# Altium schematic sheet C2000Connector.SchDoc
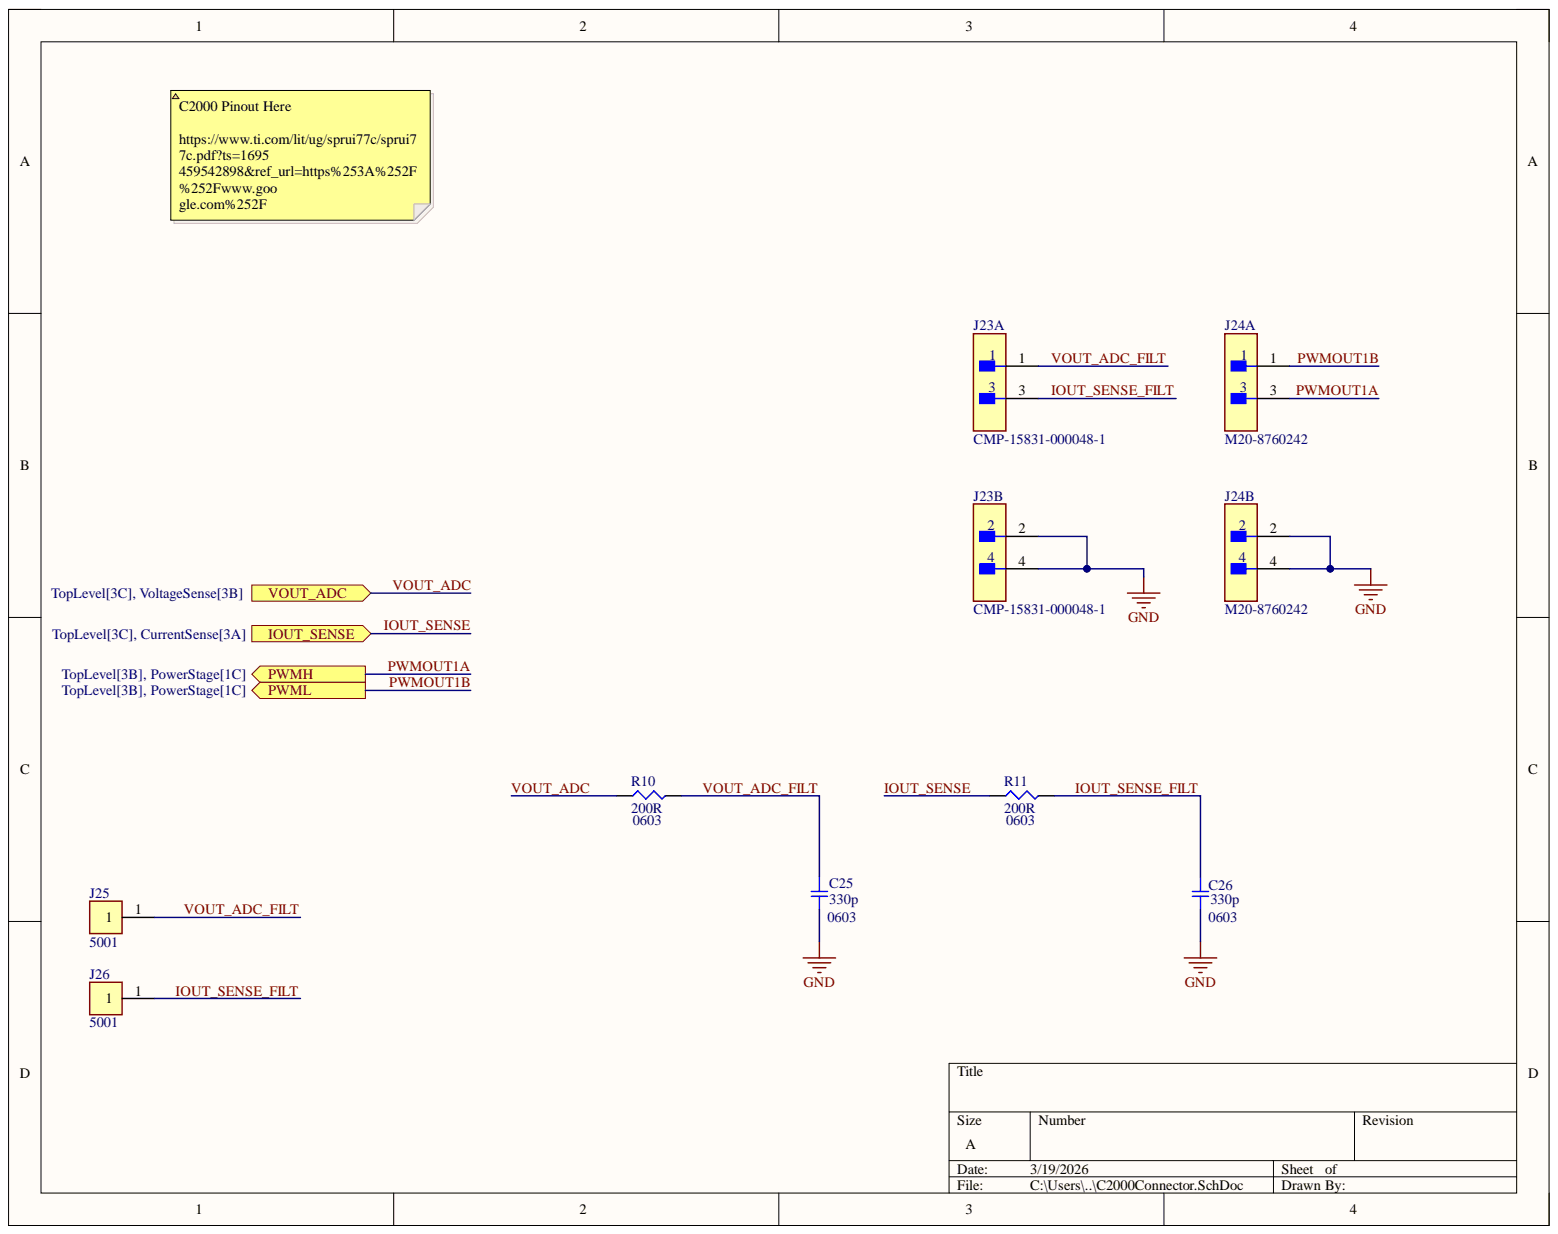
[diagram p0: C2000Connector.SchDoc]

## Parts
C25  0603  value 330p  [SMD-0603C]
C26  0603  value 330p  [SMD-0603C]
J23  CMP-15831-000048-1  [FP-M20-8760242-MFG]  (parts A,B)
J24  M20-8760242  [FP-M20-8760242-MFG]  (parts A,B)
J25  5001  [FP-5001-MFG]
J26  5001  [FP-5001-MFG]
R10  0603  value 200R  [SMD-0603-RES]
R11  0603  value 200R  [SMD-0603-RES]

## Ports
IOUT_SENSE, PWMH, PWML, VOUT_ADC

## Nets
GND: C25.2 C26.2 J23.2 J23.4 J24.2 J24.4
IOUT_SENSE: R11.1 ; port IOUT_SENSE
IOUT_SENSE_FILT: C26.1 J23.3 J26.1 R11.2
PWMOUT1A: J24.3 ; port PWMH
PWMOUT1B: J24.1 ; port PWML
VOUT_ADC: R10.1 ; port VOUT_ADC
VOUT_ADC_FILT: C25.1 J23.1 J25.1 R10.2

## Library data of the parts
C25 C26 = CMP-003-000007-1: CAP CER 330PF 50V NP0 0603; Manufacturer: Murata Electronics North America; Part Number: GRM1885C1H331GA01D; @ qty: 0; Minimum Quantity: 1; Temperature Coefficient: C0G, NP0; Tolerance: ±2%; Unit Price (USD): 0.16; Applications: General Purpose; Component Kind: Standard; Component Type: Standard; Datasheets: http://www.murata.com/~/media/webrenewal/support/library/catalog/products/capacitor/mlcc/c02e.pdf; Design Item ID: GRM1885C1H331GA01D; Digi-Key Part Number: 490-11460-1-ND; Factory Stock: 0; Features: -; Height - Seated (Max): -; Image: //media.digikey.com/Renders/Murata%20Renders/ZRB-SERIES-0402.jpg; Lead Spacing: -; Lead Style: -; Mounting Type: Surface Mount, MLCC; Operating Temperature: -55°C ~ 125°C; Package / Case: 0603 (1608 Metric); Packaging: Cut Tape (CT); Part Status: Active; Pin Count: 2; Quantity Available: 7231; Ratings: -; Series: GRM; Size / Dimension: 0.063" L x 0.031" W (1.60mm x 0.80mm); Source: PV Buck v2.SCHLIB; Thickness (Max): 0.035" (0.90mm); Voltage: 50V; Library Name: Ishaan Gupta
J23 = CMP-004-000007-1: CONN HEADER SMD 4POS 2.54MM; Manufacturer: Harwin; Manufacturer Part Number: M20-8760242; Connector Type: Male Pin; Contact Resistance: 25mR; Gender: Male; Max Current Rating: 3A; Number of Contacts: 4; Row Spacing: 0.1inch; Color: Black; Component Kind: Standard; Component Type: Standard; Contact Material: Bronze; Contact Mating Length: 0.228inch; Contact Plating: Gold; Contact Type: Male Pin; Depth: 5.08mm; Design Item ID: CMP-15831-000048-1; Housing Color: Black; Housing Material: Nylon; Length: 5.08mm; Max Operating Temperature: 105°C; Min Operating Temperature: -40°C; Mount: Surface Mount; Number of Positions: 4; Number of Rows: 2; Orientation: Straight; Package Quantity: 1000; Packaging: Bulk; Pin Count: 4; Pitch: 2.54mm; Radiation Hardening: No; RoHS Compliant: Yes; Series: M20; Source: PV Buck v2.SCHLIB; Termination: Solder; Library Name: Ishaan Gupta
J24 = CMP-004-000007-1: CONN HEADER SMD 4POS 2.54MM; Manufacturer: Harwin; Connector Type: Male Pin; Contact Resistance: 25mR; Gender: Male; Max Current Rating: 3A; Number of Contacts: 4; Row Spacing: 0.1inch; Color: Black; Component Kind: Standard; Component Type: Standard; Contact Material: Bronze; Contact Mating Length: 0.228inch; Contact Plating: Gold; Contact Type: Male Pin; Depth: 5.08mm; Design Item ID: CMP-15831-000048-1; Housing Color: Black; Housing Material: Nylon; Length: 5.08mm; Manufacturer Part Number: M20-8760242; Max Operating Temperature: 105°C; Min Operating Temperature: -40°C; Mount: Surface Mount; Number of Positions: 4; Number of Rows: 2; Orientation: Straight; Package Quantity: 1000; Packaging: Bulk; Pin Count: 4; Pitch: 2.54mm; Radiation Hardening: No; RoHS Compliant: Yes; Series: M20; Source: PV Buck v2.SCHLIB; Termination: Solder; Library Name: Ishaan Gupta
J25 J26 = CMP-010-000010-1: PC TEST POINT MINIATURE BLACK; Manufacturer: Keystone Electronics; Body Material: Bronze; Hole Diameter: 1.6mm; Inside Diameter: 1.27mm; Insulation: Insulated; Number of Contacts: 1; Outside Diameter: 2.54mm; Plating: Silver; Color: Black; Component Kind: Standard; Component Type: Standard; Contact Material: Bronze; Contact Plating: Silver; Design Item ID: CMP-2000-05181-2; Diameter: 2.54mm; Flammability Rating: UL94 V-0; Height: 0.3inch; Housing Color: Black; Insulation Material: Nylon; Lead Free: Lead Free; Length: 7.62mm; Manufacturer Part Number: 5001; Material: Nylon; Mount: Through Hole; Number of Ports: 1; Orientation: Straight; Package Quantity: 100; Packaging: Bulk; Pin Count: 1; REACH SVHC: No SVHC; RoHS Compliant: Yes; Series: THM; Source: PV Buck v2.SCHLIB; Termination: Through Hole; Library Name: Ishaan Gupta
R10 R11 = CMP-009-000016-1: RES SMD 200 OHM 1% 1/10W 0603; Manufacturer: Panasonic Electronic Components; Part Number: ERJ-3EKF2000V; @ qty: 1500; Factory Stock: 0; Minimum Quantity: 1; Power (Watts): 0.1W, 1/10W; Temperature Coefficient: ±100ppm/°C; Unit Price (USD): 0.00379; Component Kind: Standard; Component Type: Standard; Composition: Thick Film; Datasheets: http://industrial.panasonic.com/www-cgi/jvcr13pz.cgi?E+PZ+3+AOA0002+ERJ3EKF2000V+7+WW; Design Item ID: ERJ-3EKF2000V; Digi-Key Part Number: P200HCT-ND; Failure Rate: -; Features: Automotive AEC-Q200; Height - Seated (Max): 0.022" (0.55mm); Image: //media.digikey.com/Photos/Panasonic%20Photos/MFG_ERJ-P6W%20Series.jpg; Number of Terminations: 2; Operating Temperature: -55°C ~ 155°C; Package / Case: 0603 (1608 Metric); Packaging: Cut Tape (CT); Part Status: Active; Pin Count: 2; Quantity Available: 74155; Series: ERJ; Size / Dimension: 0.063" L x 0.031" W (1.60mm x 0.80mm); Source: PV Buck v2.SCHLIB; Supplier Device Package: 0603; Tolerance: ±1%; Library Name: Ishaan Gupta
